# Altium schematic sheet ESP-Grow_OLED_Rev_A.SchDoc(ESP-Grow_OLED_Rev_A)
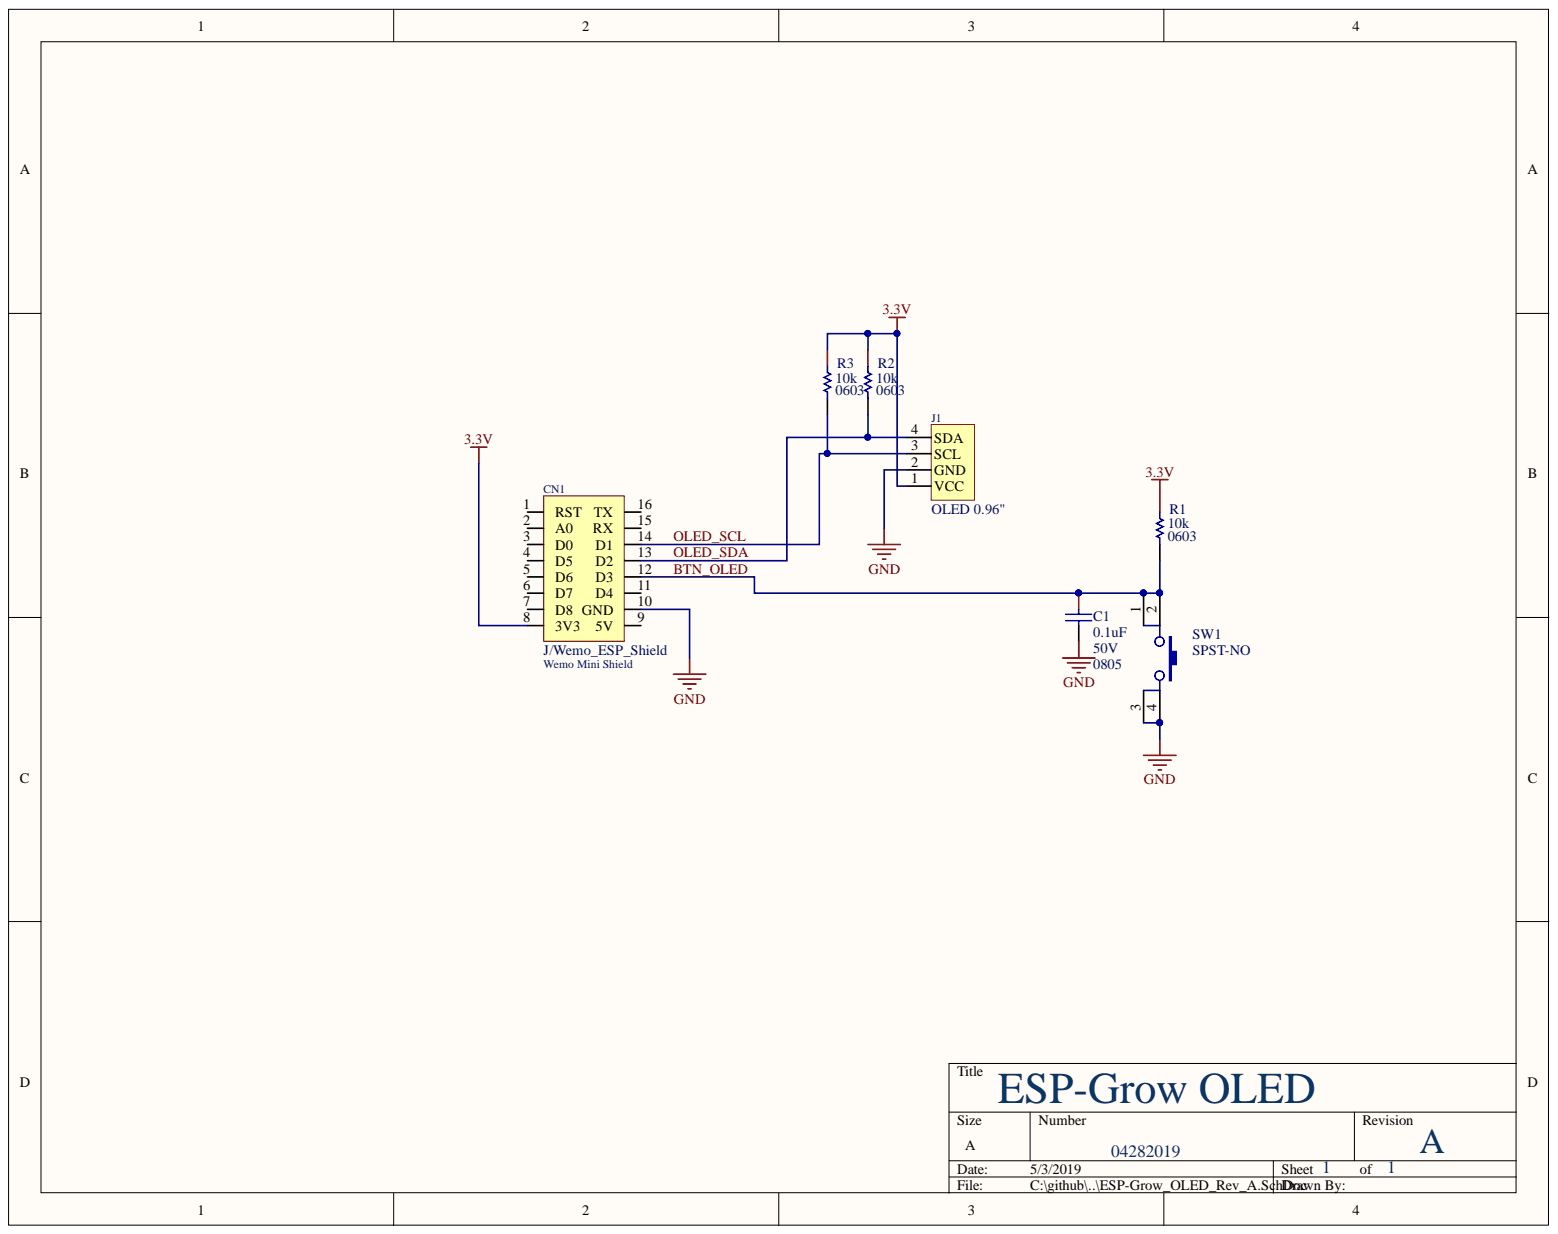
[diagram p0: ESP-Grow_OLED_Rev_A.SchDoc]

## Parts
C1  0.1uF  Voltage (Rated): 50V  Package (Imperial): 0805  [CAPC0805(2012)_L]
CN1  J/Wemo_ESP_Shield  Name: Wemo Mini Shield  [Wemos_d1]
J1  OLED 0.96"  [OLED_0.96_I2C]
R1  Package (Imperial): 0603  [RESC0603(1608)_M]  (hidden comment: 10K)
R2  Package (Imperial): 0603  [RESC0603(1608)_M]  (hidden comment: 10K)
R3  Package (Imperial): 0603  [RESC0603(1608)_M]  (hidden comment: 10K)
SW1  Circuit: SPST-NO  [SW TACT Red 6mm x 6mm]  (hidden comment: PTS645SM43SMTR92 LFS)

## Nets
3.3V: CN1.8(3V3) J1.1(VCC) R1.1 R2.1 R3.1
GND: C1.2 CN1.10(GND) J1.2(GND) SW1.3 SW1.4
BTN_OLED: C1.1 CN1.12(D3) R1.2 SW1.1 SW1.2
OLED_SCL: CN1.14(D1) J1.3(SCL) R3.2
OLED_SDA: CN1.13(D2) J1.4(SDA) R2.2

Not connected: CN1 1(RST) 2(A0) 3(D0) 4(D5) 5(D6) 6(D7) 7(D8) 9(5V) 11(D4) 15(RX) 16(TX)

## Library data of the parts
C1 = CMP-007-0047-08: CAP CER 0.1UF 50V 10% X7R 0805; Temperature Coefficient: X8R; Temperature (Min): -55°C; Temperature (Max): 150°C; Package (Metric): 2012; Dielectric Material: Ceramic; Capacitance: 100nF; Width: 1.25mm; Tolerance: 10%; Mounting Type: SMD; Length: 2mm; Height: 1.4mm; Component Kind: Standard; Library Name: OLogic Nexus Vault
CN1 = J/Wemo_ESP_Shield: Wemo Mini Shield for ESP-12; Component Kind: Standard; Library Name: Kyle_Lib_04-20-19.IntLib
J1 = OLED_0.96_I2C: SSD1306 I2C OLED 0.96"; Component Kind: Standard; Library Name: Kyle_Lib_04-20-19.IntLib
R1-R3 = CMP-007-0359-12: RES 10K OHM 1/10W 1% 0603 SMD; Temperature Coefficient: ±100ppm/°C; Power: 100mW; Package (Metric): 1608; Tolerance: 1%; Termination: SMD/SMT; Simulation: RESISTOR; Resistance: 10k; Height: 550µm; Component Kind: Standard; Library Name: OLogic Nexus Vault
SW1 = PTS645SM43SMTR92 LFS: SWITCH TACTILE SPST-NO 0.05A 12V; Manufacturer: C&K; Switch Function: Off-Mom; Outline: 6.00mm x 6.00mm; Operating Force: 160gf; Mechanical Life: 100,000 Cycles; Illumination: Non-Illuminated; Contact Rating @ Voltage: 0.05A @ 12VDC; Actuator Type: Standard; Actuator Orientation: Top Actuated; Actuator Material: Polyamide (PA66), Nylon 6/6; Actuator Height off PCB, Vertical: 4.30mm; Termination Style: Gull Wing; Switch Travel: -; Stock 1: 234699; RoHS: RoHS Compliant; Pricing 1: 1=0.15, 10=0.147, 25=0.1416, 50=0.1366, 100=0.129, 250=0.12144, 500=0.11638 (USD); Pin Count: 4; Part Status: Active; Packaging: Cut Tape (CT); Operating Temperature: -20°C ~ 60°C; Mounting Type: Surface Mount; Manufacturer Part Number: PTS645SM43SMTR92 LFS; Ingress Protection: -; Illumination Voltage (Nominal): -; Illumination Type, Color: -; Features: Blue Actuator; Designator: PTS645SM43SMTR92 LFS; Component Name: PTS645SM43SMTR92 LFS; ComponentLink1URL: http://www.ckswitches.com/media/1471/pts645.pdf; ComponentLink1Description: http://www.ckswitches.com/media/1471/pts645.pdf; Component Kind: Standard; Actuator Length, Right Angle: -
